# Altium schematic sheet mboard.SchDoc
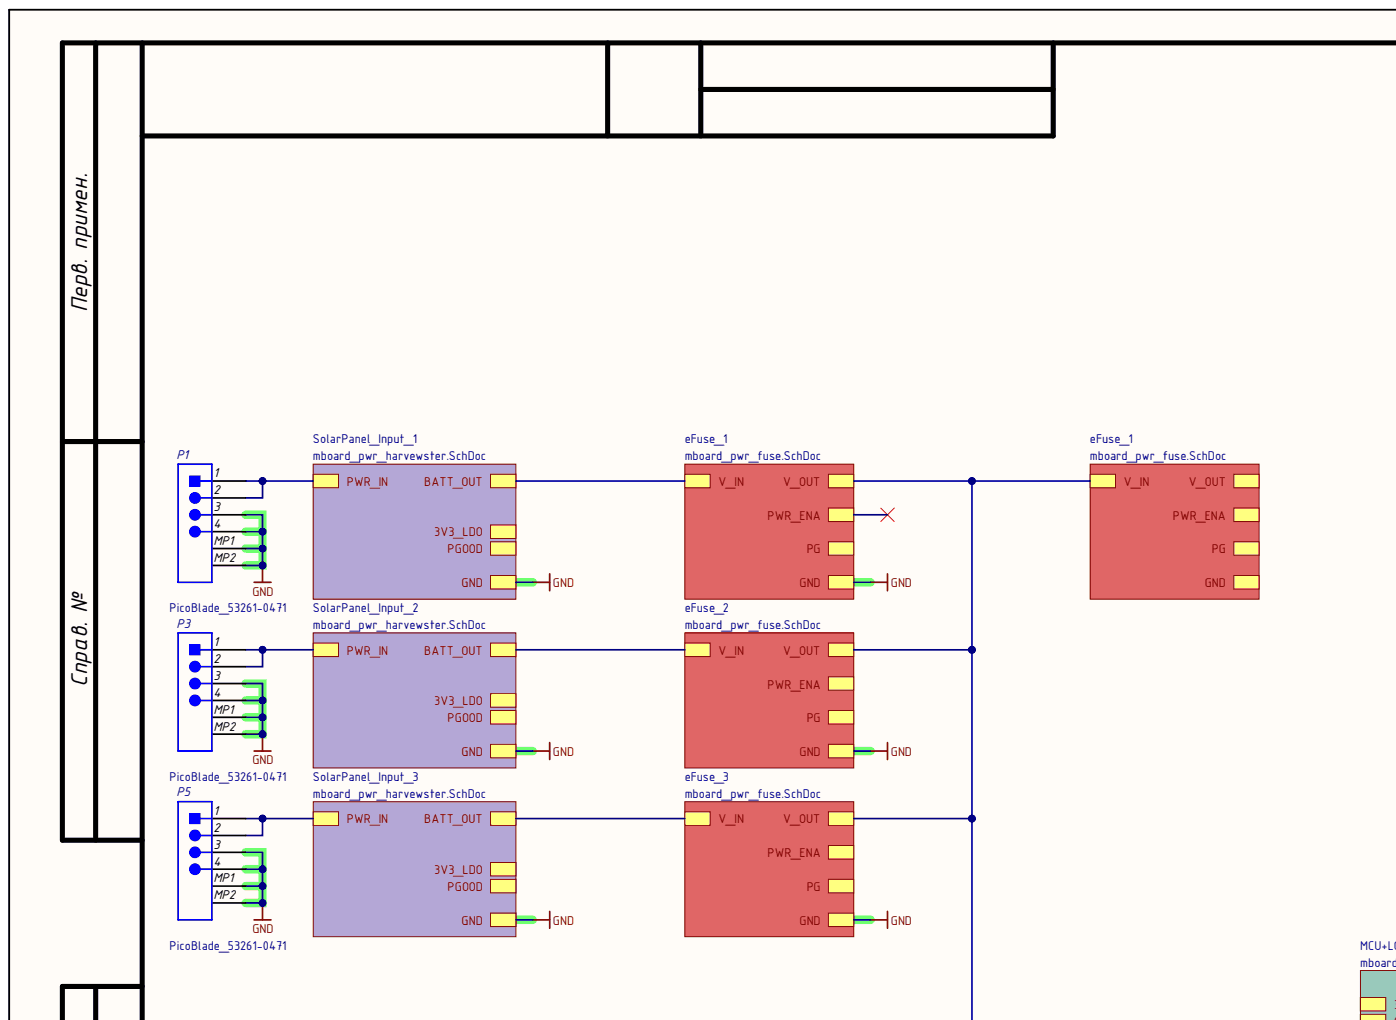
[diagram p0: mboard.SchDoc, top left]
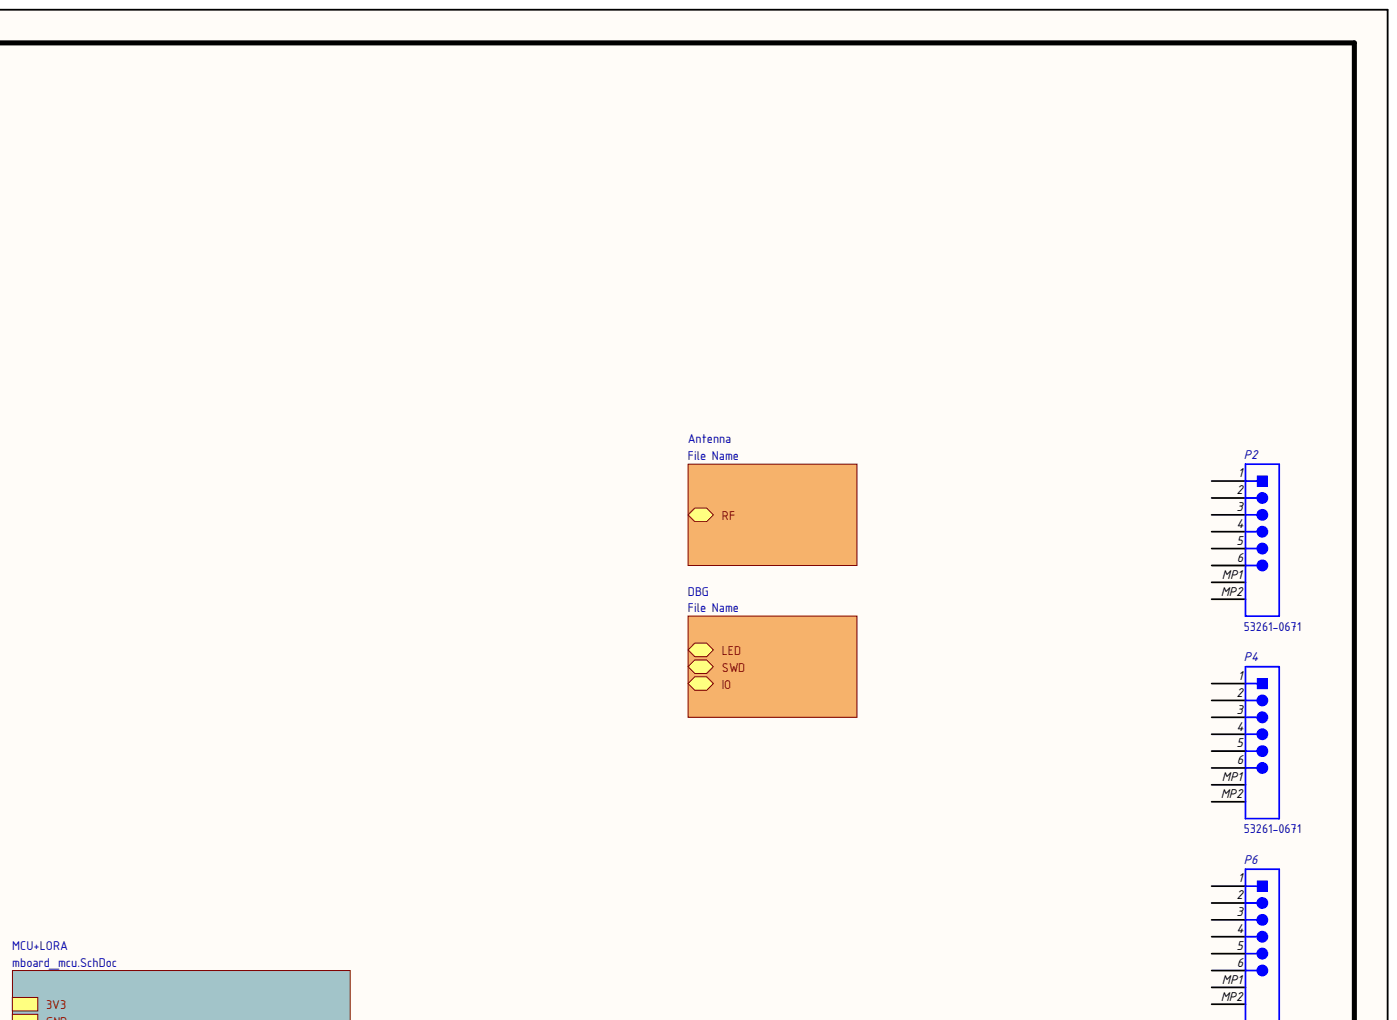
[diagram p1: mboard.SchDoc, top right]
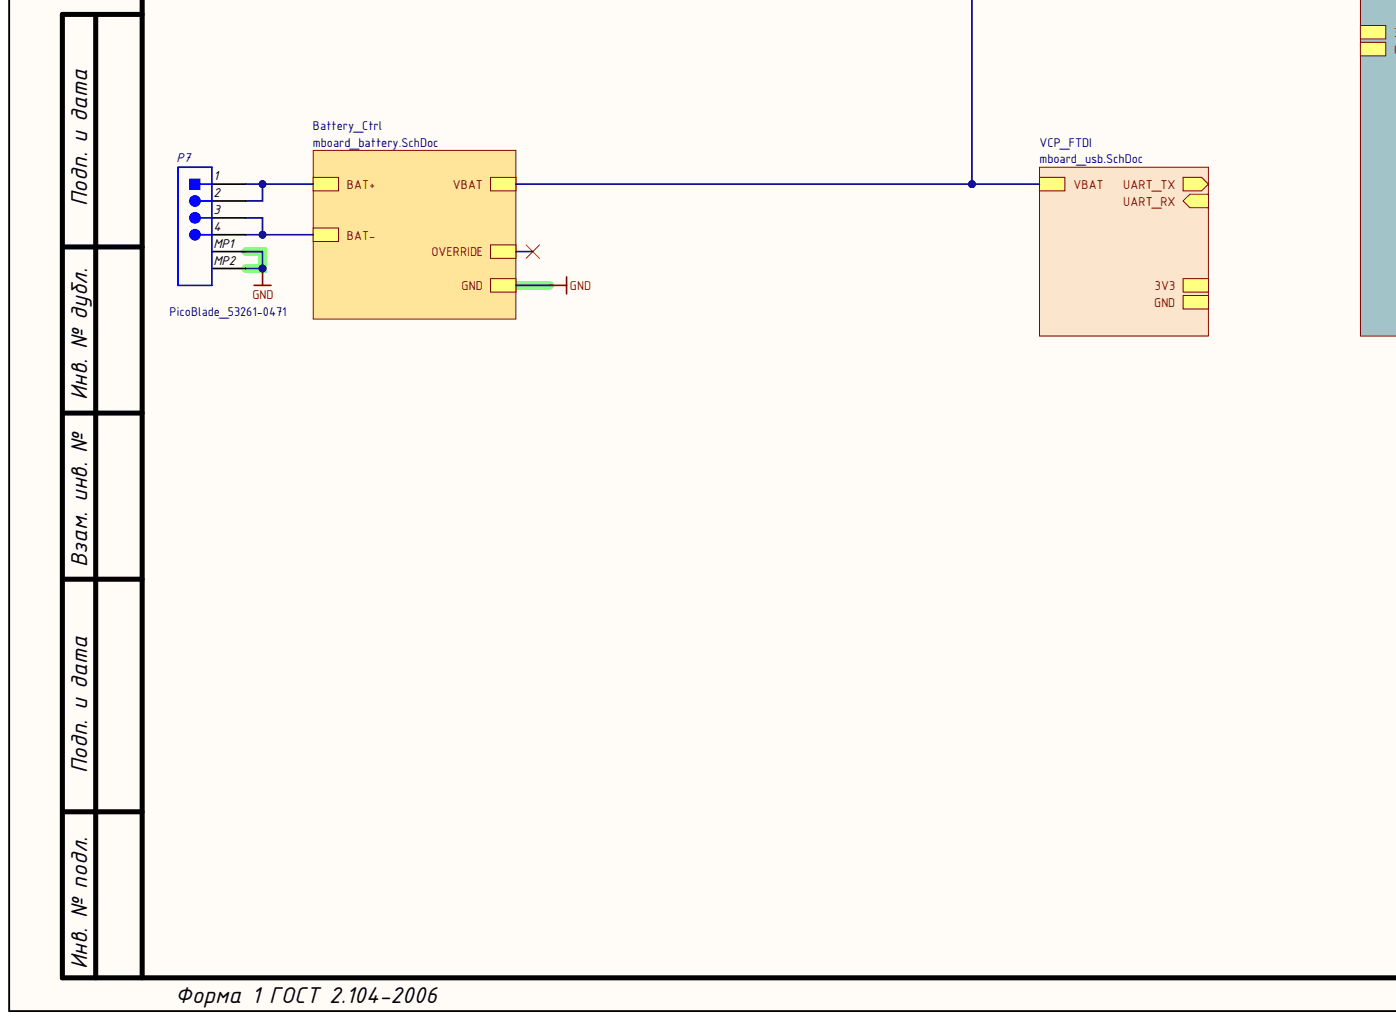
[diagram p2: mboard.SchDoc, bottom left]
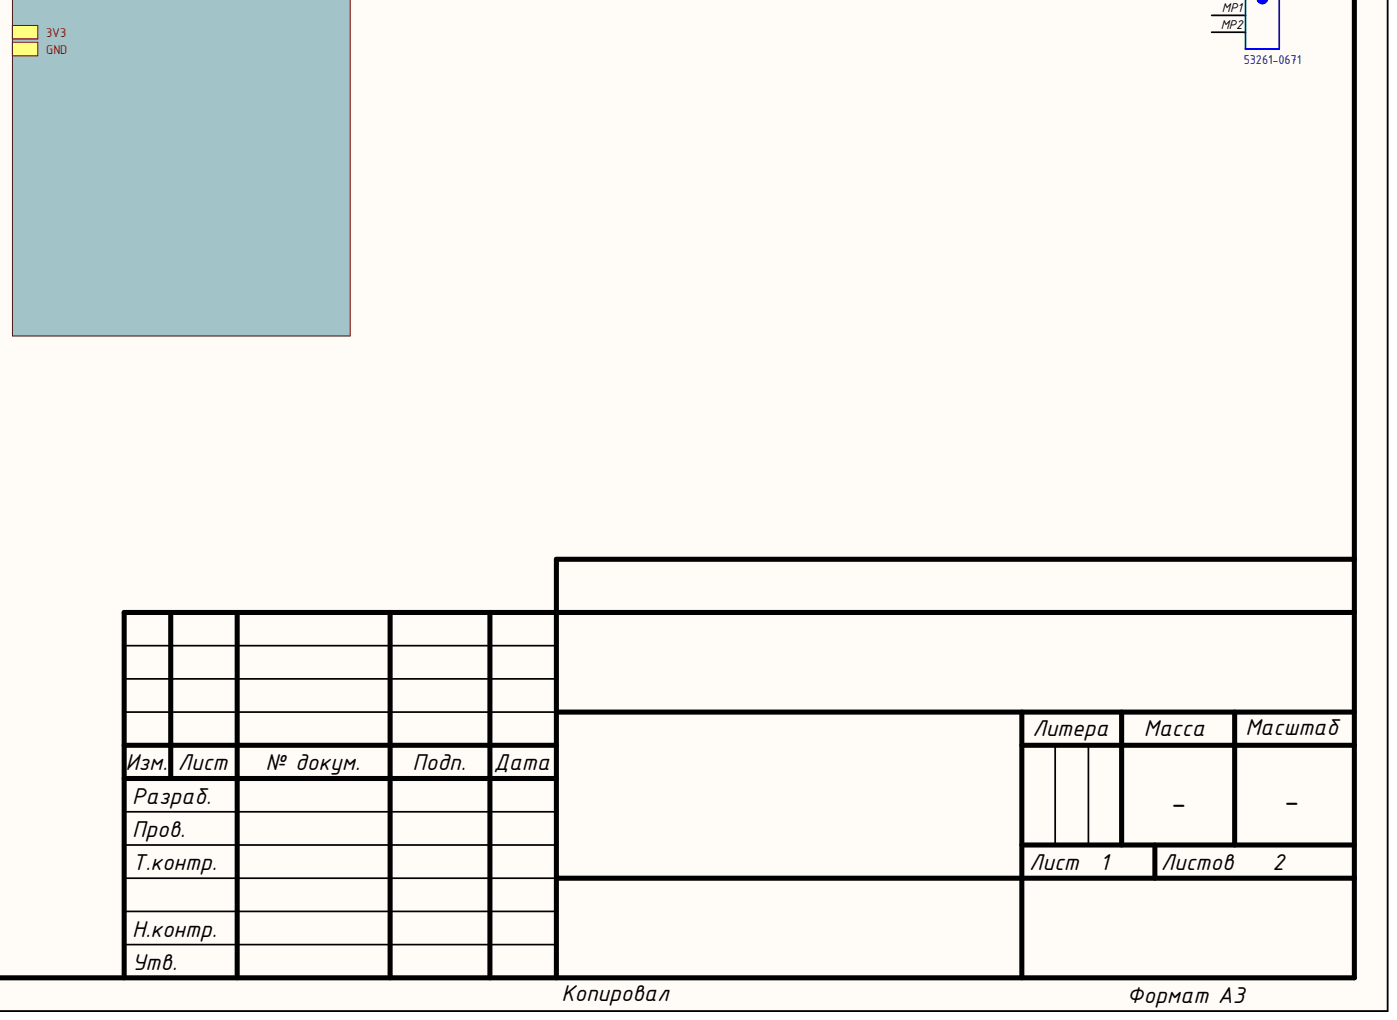
[diagram p3: mboard.SchDoc, bottom right]

## Parts
P1  PicoBlade_53261-0471  [53261-0471]
P2  53261-0671  [53261-0671]
P3  PicoBlade_53261-0471  [53261-0471]
P4  53261-0671  [53261-0671]
P5  PicoBlade_53261-0471  [53261-0471]
P6  53261-0671  [53261-0671]
P7  PicoBlade_53261-0471  [53261-0471]

## Nets
GND: P1.3 P1.4 P1.MP1 P1.MP2 P3.3 P3.4 P3.MP1 P3.MP2 P5.3 P5.4 P5.MP1 P5.MP2 P7.MP1 P7.MP2
NetP1_1: P1.1 P1.2
NetP2_1: P2.1
NetP2_2: P2.2
NetP2_3: P2.3
NetP2_4: P2.4
NetP2_5: P2.5
NetP2_6: P2.6
NetP2_MP1: P2.MP1
NetP2_MP2: P2.MP2
NetP3_1: P3.1 P3.2
NetP4_1: P4.1
NetP4_2: P4.2
NetP4_3: P4.3
NetP4_4: P4.4
NetP4_5: P4.5
NetP4_6: P4.6
NetP4_MP1: P4.MP1
NetP4_MP2: P4.MP2
NetP5_1: P5.1 P5.2
NetP6_1: P6.1
NetP6_2: P6.2
NetP6_3: P6.3
NetP6_4: P6.4
NetP6_5: P6.5
NetP6_6: P6.6
NetP6_MP1: P6.MP1
NetP6_MP2: P6.MP2
NetP7_1: P7.1 P7.2
NetP7_3: P7.3 P7.4
3V3:
3V3_LDO:
BATT_OUT:
IO:
LED:
OVERRIDE:
PG:
PGOOD:
PWR_ENA:
RF:
SWD:
UART_RX:
UART_TX:
V_IN:
V_OUT:

## Library data of the parts
P1 P3 P5 P7 = PicoBlade_53261-0471: Male Header, Pitch 1.25 mm, 1 x 4 Position, Height 3.4 mm, -40 to 85 degC, RoHS, Tape and Reel; Потребление, Вт: 0.0; Component Kind: Standard; ComponentLink1Description: DataSheet; ComponentLink1URL: http://www.molex.com/pdm_docs/sd/532610471_sd.pdf; Library Name: Connector.IntLib; Назначение: Male Header, Pitch 1.25 mm, 1 x 4 Position, Height 3.4 mm, -40 to 85 degC, RoHS, Tape and Reel; Наименование: PicoBlade_53261-0471
P2 P4 P6 = PicoBlade_53261-0671: Male Header, Pitch 1.25 mm, 1 x 6 Position, Height 3.4 mm, -40 to 85 degC, RoHS, Tape and Reel; Brand: Molex; Current Rating: 1A; Mounting Angle: Right; Number of Positions: 4; Number of Positions / Contacts: 6; Pitch: 1.25mm; Voltage Rating: 125V; Потребление, Вт: 0.0; Component Kind: Standard; ComponentLink1Description: DataSheet; ComponentLink1URL: http://www.molex.com/pdm_docs/sd/532610671_sd.pdf; Contact Material: PhosphorBronze; Contact Plating: Tin; Datasheet URL: http://www.molex.com/pdm_docs/sd/532610471_sd.pdf; Datasheet Version: Rev. G, 01/2012; Factory Pack Quantity: 1000; Housing Material: Thermoplastic; Library Name: Connector.IntLib; Manufacturer: Molex; Manufacturer URL: http://www.molex.com/; Mounting Style: SMD/SMT; Number of Rows: 1; Package Description: 1.25mm Pitch PicoBlade(TM) Header, Surface Mount, Right Angle, Lead-Free, 6 Circuits; Packaging: Reel; Product Category: Headers&WireHousings; Product Type: Headers; RoHS: Details; Series: 53261; Termination Style: SMD; Tradename: PicoBlade; Type: Shrouded; Назначение: Male Header, Pitch 1.25 mm, 1 x 6 Position, Height 3.4 mm, -40 to 85 degC, RoHS, Tape and Reel; Наименование: 53261-0671
